# Altium schematic sheet Flow-Sensor.SchDoc(U_Flow-Sensor)
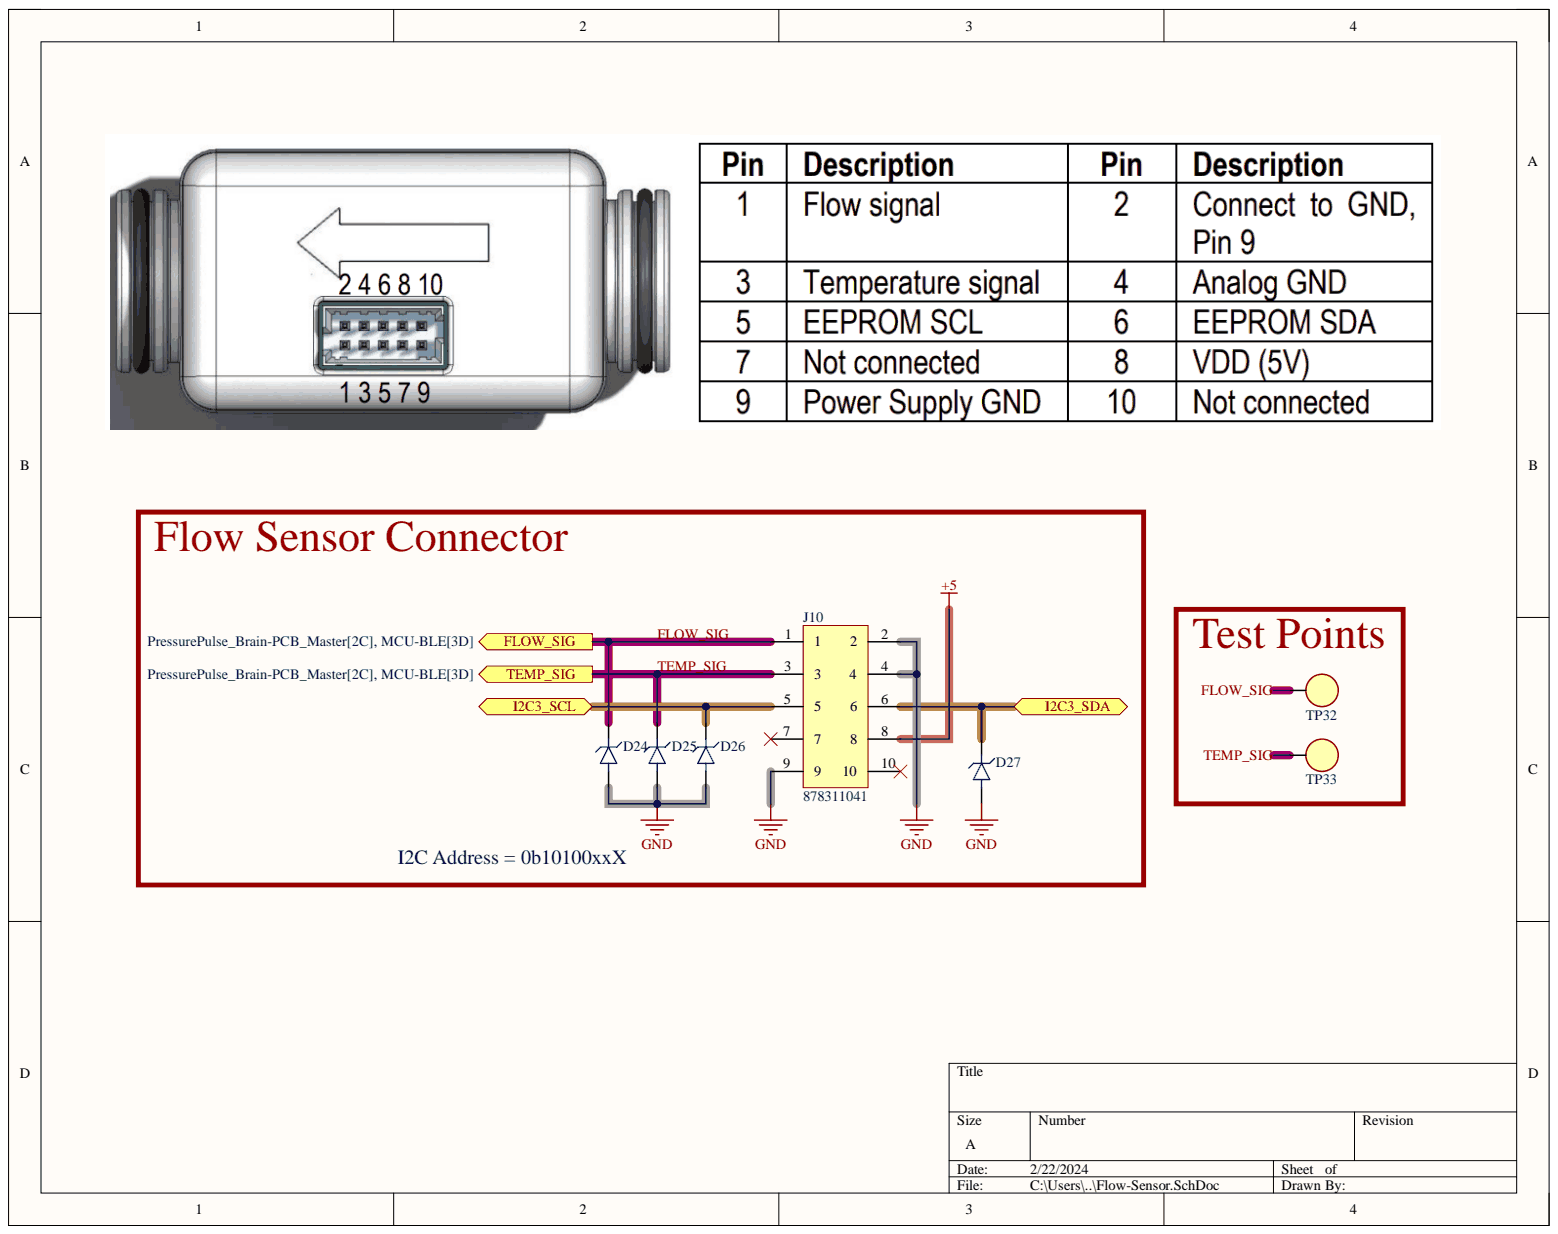
[diagram p0: Flow-Sensor.SchDoc]

## Parts
D24  RCLAMP0521P.TCT  [RCLAMP0521P.TCT]  (value not drawn)
D25  RCLAMP0521P.TCT  [RCLAMP0521P.TCT]  (value not drawn)
D26  RCLAMP0521P.TCT  [RCLAMP0521P.TCT]  (value not drawn)
D27  RCLAMP0521P.TCT  [RCLAMP0521P.TCT]  (value not drawn)
J10  878311041  [878311041]
TP32  RH-5019  [TP - RH-5019]  (value not drawn)
TP33  RH-5019  [TP - RH-5019]  (value not drawn)

## Ports
FLOW_SIG, I2C3_SCL, I2C3_SDA, TEMP_SIG

## Nets
+5: J10.8
GND: D24.A D25.A D26.A D27.A J10.2 J10.4 J10.9
FLOW_SIG: D24.K J10.1 TP32.1 ; port FLOW_SIG
I2C3_SCL: D26.K J10.5 ; port I2C3_SCL
I2C3_SDA: D27.K J10.6 ; port I2C3_SDA
TEMP_SIG: D25.K J10.3 TP33.1 ; port TEMP_SIG
NetJ10_7: J10.7
NetJ10_10: J10.10

## Library data of the parts
D24-D27 = TVS DIODE: 25V Clamp 4A (8/20µs) Ipp Tvs Diode Surface Mount SLP1006P2; MFR: Semtech Corporation; Type: SMD; Component Kind: Standard; Library Name: PressurePulse.SchLib; MFR PN: RCLAMP0521P.TCT; Value: RCLAMP0521P.TCT
J10 = MOLEX 10 POS 2mm TH: MFR: Molex; Value: DNS; Component Kind: Standard; Description: 878311041; Library Name: PressurePulse.SchLib; MFR PN: 878311041
TP32 TP33 = TEST POINT: MFR: ronghe; Component Kind: Standard; JLCPCB PN: C5199800; Library Name: PressurePulse.SchLib; MFR PN: RH-5019; Value: RH-5019
